# Altium schematic sheet cs301_template.SchDoc
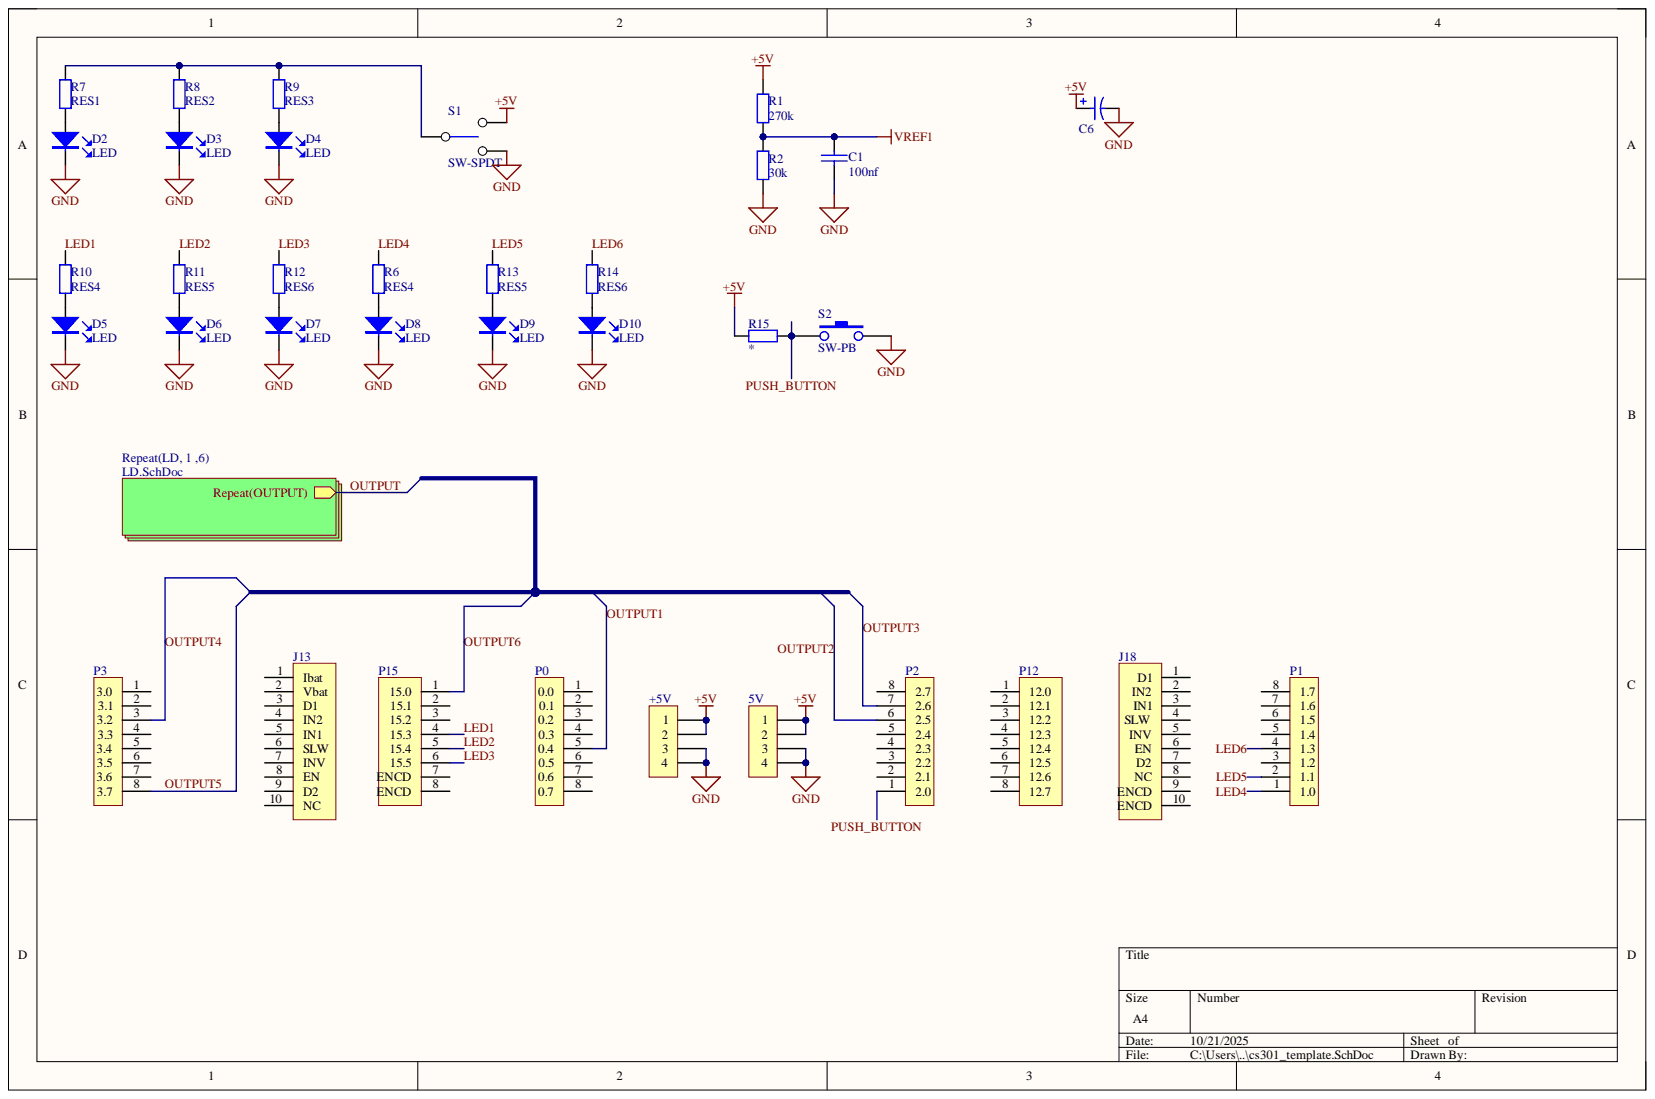
[diagram p0: cs301_template.SchDoc]

## Parts
5V  5V  [IDC4]
+5V  5V  [IDC4]
C1  100nf  [0805 CAP]
C6  (no value)  [CAP POL 8x5.4mm SMT]
D2  LED  [LED0.1/5MM]
D3  LED  [LED0.1/5MM]
D4  LED  [LED0.1/5MM]
D5  LED  [LED0.1/5MM]
D6  LED  [LED0.1/5MM]
D7  LED  [LED0.1/5MM]
D8  LED  [LED0.1/5MM]
D9  LED  [LED0.1/5MM]
D10  LED  [LED0.1/5MM]
J13  CON10  [idc10]  (value not drawn)
J18  CON10  [IDC10]  (value not drawn)
P0  P0  [idc8]
P1  P1  [idc8]
P2  P2  [idc8]
P3  P3  [idc8]
P12  P12  [idc8]
P15  P15  [idc8]
R1  270k  [0805 RES]
R2  30k  [0805 RES]
R6  RES4  [0805 RES]
R7  RES1  [0805 RES]
R8  RES2  [0805 RES]
R9  RES3  [0805 RES]
R10  RES4  [0805 RES]
R11  RES5  [0805 RES]
R12  RES6  [0805 RES]
R13  RES5  [0805 RES]
R14  RES6  [0805 RES]
R15  (no value)  [0805 RES]
S1  SW-SPDT  [SW-SPDT]
S2  SW-PB  [SW-PB]

## Nets
+5V: 5V.1 5V.2 +5V.1 +5V.2 C6.1 R1.2 R15.1 S1.1
GND: 5V.3 5V.4 +5V.3 +5V.4 C1.2 C6.2 D2.K D3.K D4.K D5.K D6.K D7.K D8.K D9.K D10.K R2.1 S1.3 S2.2
VREF1: C1.1 R1.1 R2.2
LED1: P15.4(15.3) R10.2
LED2: P15.5(15.4) R11.2
LED3: P15.6(15.5) R12.2
LED4: P1.1(1.0) R6.2
LED5: P1.2(1.1) R13.2
LED6: P1.4(1.3) R14.2
OUTPUT1: P0.5(0.4)
OUTPUT2: P2.6(2.5)
OUTPUT3: P2.7(2.6)
OUTPUT4: P3.3(3.2)
OUTPUT5: P3.8(3.7)
OUTPUT6: P15.1(15.0)
PUSH_BUTTON: P2.1(2.0) R15.2 S2.1
NetD2_A: D2.A R7.1
NetD3_A: D3.A R8.1
NetD4_A: D4.A R9.1
NetD5_A: D5.A R10.1
NetD6_A: D6.A R11.1
NetD7_A: D7.A R12.1
NetD8_A: D8.A R6.1
NetD9_A: D9.A R13.1
NetD10_A: D10.A R14.1
NetJ13_1: J13.1(Ibat)
NetJ13_2: J13.2(Vbat)
NetJ13_3: J13.3(D1)
NetJ13_4: J13.4(IN2)
NetJ13_5: J13.5(IN1)
NetJ13_6: J13.6(SLW)
NetJ13_7: J13.7(INV)
NetJ13_8: J13.8(EN)
NetJ13_9: J13.9(D2)
NetJ13_10: J13.10(NC)
NetJ18_1: J18.1(D1)
NetJ18_2: J18.2(IN2)
NetJ18_3: J18.3(IN1)
NetJ18_4: J18.4(SLW)
NetJ18_5: J18.5(INV)
NetJ18_6: J18.6(EN)
NetJ18_7: J18.7(D2)
NetJ18_8: J18.8(NC)
NetJ18_9: J18.9(ENCD)
NetJ18_10: J18.10(ENCD)
NetP0_1: P0.1(0.0)
NetP0_2: P0.2(0.1)
NetP0_3: P0.3(0.2)
NetP0_4: P0.4(0.3)
NetP0_6: P0.6(0.5)
NetP0_7: P0.7(0.6)
NetP0_8: P0.8(0.7)
NetP1_3: P1.3(1.2)
NetP1_5: P1.5(1.4)
NetP1_6: P1.6(1.5)
NetP1_7: P1.7(1.6)
NetP1_8: P1.8(1.7)
NetP2_2: P2.2(2.1)
NetP2_3: P2.3(2.2)
NetP2_4: P2.4(2.3)
NetP2_5: P2.5(2.4)
NetP2_8: P2.8(2.7)
NetP3_1: P3.1(3.0)
NetP3_2: P3.2(3.1)
NetP3_4: P3.4(3.3)
NetP3_5: P3.5(3.4)
NetP3_6: P3.6(3.5)
NetP3_7: P3.7(3.6)
NetP12_1: P12.1(12.0)
NetP12_2: P12.2(12.1)
NetP12_3: P12.3(12.2)
NetP12_4: P12.4(12.3)
NetP12_5: P12.5(12.4)
NetP12_6: P12.6(12.5)
NetP12_7: P12.7(12.6)
NetP12_8: P12.8(12.7)
NetP15_2: P15.2(15.1)
NetP15_3: P15.3(15.2)
NetP15_7: P15.7(ENCD)
NetP15_8: P15.8(ENCD)
NetR7_2: R7.2 R8.2 R9.2 S1.2

## Library data of the parts
5V +5V = CON4: Connector; Component Kind: Standard; Library Name: Miscellaneous Devices.SCHLIB
C1 = CerCap_SMT_0805: SMT ceramic capacitor 0805 size; Component Kind: Standard; Library Name: COMSYS301_2025.IntLib
C6 = ElecCap_SMT_8D0: CAP ALUM 22UF 20% 50V SMD (Model: UWX1H220MCL1GB); Manufacturer: Nichicon; Manufacturer Part Number: UWX1V220MCL1GB; Capacitance 1: 22 µF; Lifetime @ Temp.: 2000 Hrs @ 85°C; Polarization: Polar; Surface Mount Land Size: 0.260" L x 0.260" W (6.60mm x 6.60mm); Applications: General Purpose; Category: Capacitors; Component Kind: Standard; ComponentLink1Description: http://nichicon-us.com/english/products/pdfs/e-uwx.pdf; ComponentLink1URL: http://nichicon-us.com/english/products/pdfs/e-uwx.pdf; ESR (Equivalent Series Resistance): -; Height - Seated (Max): 0.213" (5.40mm); Lead Spacing: -; Library Name: COMSYS301_2025.IntLib; Mounting Type: Surface Mount; Operating Temperature: -40°C ~ 85°C; Package / Case: Radial, Can - SMD; Packaging: Cut Tape (CT); Part Status: Active; Pricing 1: 1=0.59, 10=0.406, 100=0.2304, 500=0.16268 (NZD); Ratings: -; Revision: July-2002: Re-released for DXP Platform.; RoHS: RoHS Compliant; Size / Dimension: 0.248" Dia (6.30mm); Stock 1: 680; Supplier 1: Digi-Key; Supplier Part Number 1: 493-2136-1-ND; Tolerance: ±20%
J13 J18 = CON10: Connector; Component Kind: Standard; Library Name: Miscellaneous Devices.SCHLIB
P0-P3 = CON8: Connector; Component Kind: Standard; Library Name: Miscellaneous Devices.SCHLIB
P12 P15 = CON8_1: Connector; Component Kind: Standard; Library Name: Miscellaneous Devices.SCHLIB
R1 R2 R6-R15 = Res_SMT_0805: 0805 resistor; Component Kind: Standard; ComponentLink1Description: https://nz.mouser.com/datasheet/2/315/AOA0000C304-1149620.pdf; LatestRevisionDate: 17-Jul-2002; LatestRevisionNote: Re-released for DXP Platform.; Library Name: COMSYS301_2025.IntLib; PackageReference: AXIAL-0.4; Published: 8-Jun-2000; Publisher: Altium Limited
S1 = SW-SPDT: Toggle Switch; Component Kind: Standard; Library Name: COMSYS301_2025.IntLib
S2 = SW-PB: Push Button Switch; Component Kind: Standard; Library Name: COMSYS301_2025.IntLib
D2-D10 = LED: Component Kind: Standard; Library Name: COMSYS301_2025.IntLib
